# Altium schematic sheet 3 - 19V Buck.SchDoc
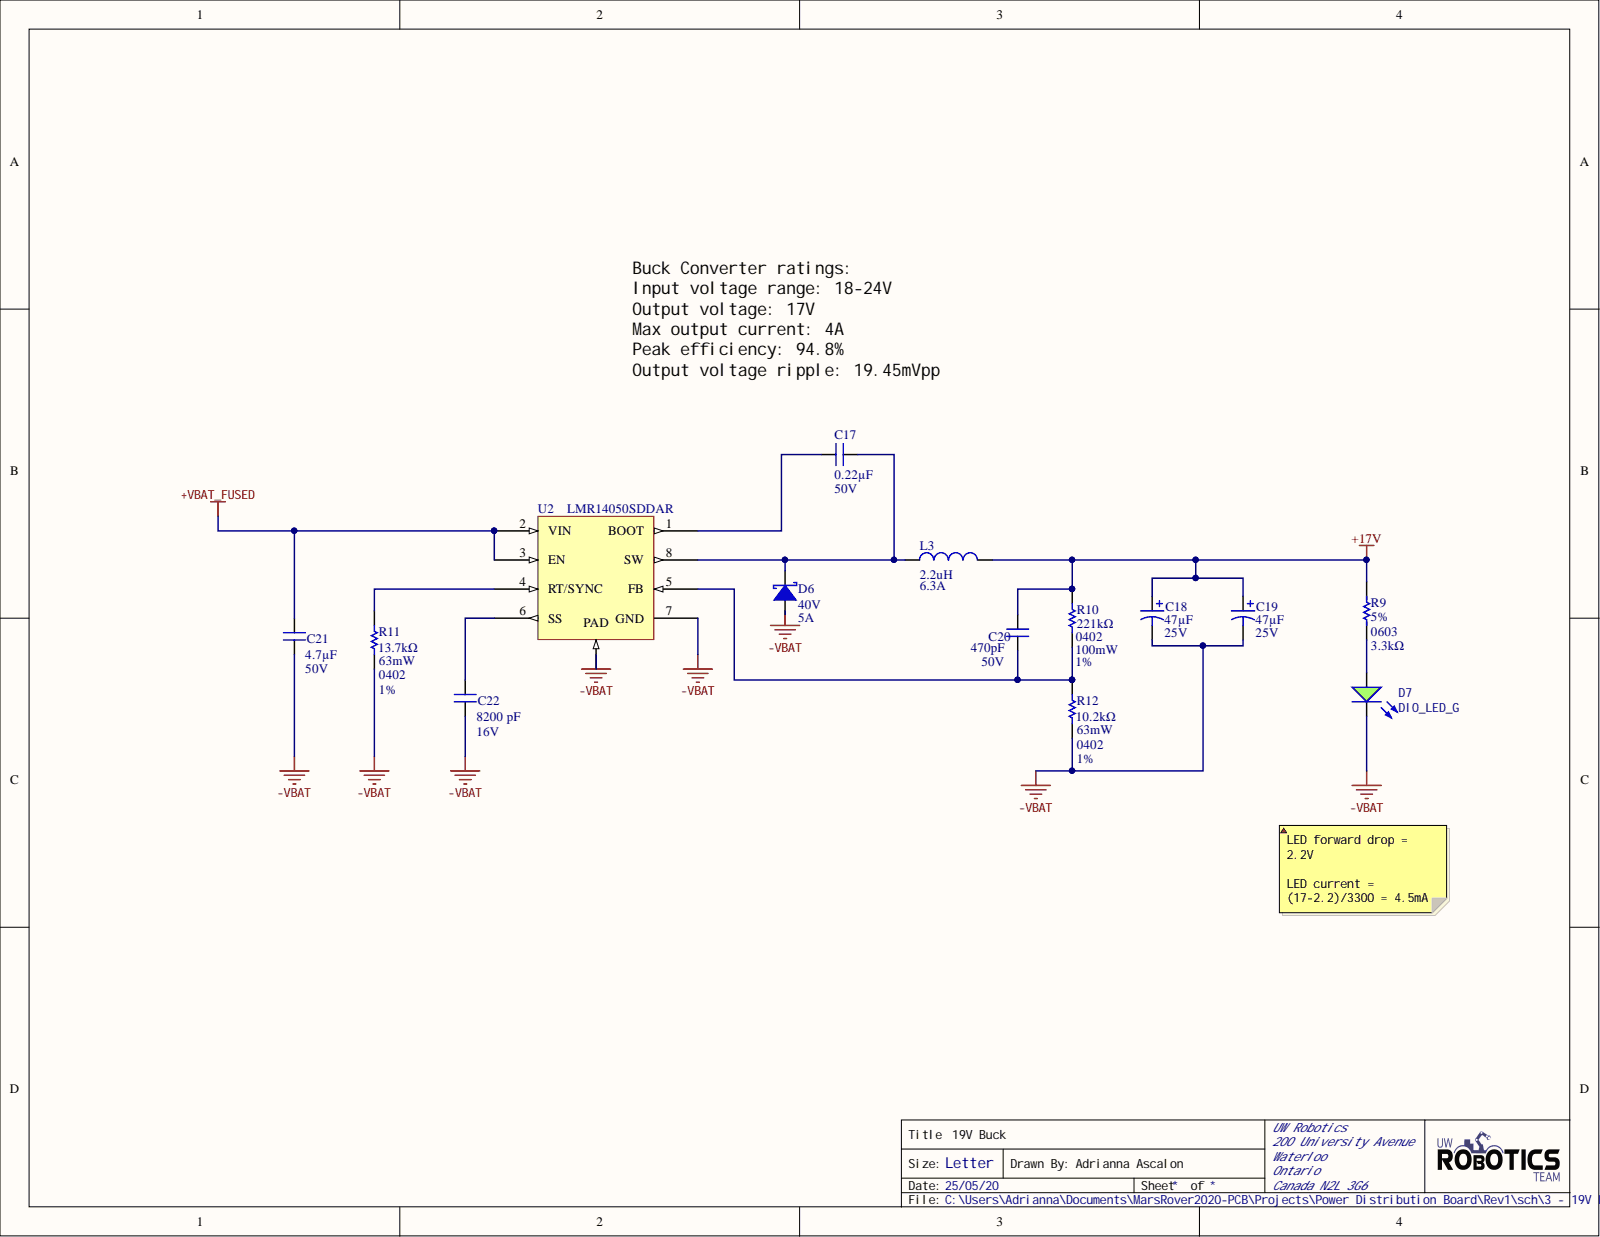
[diagram p0: 3 - 19V Buck.SchDoc]

## Parts
C17  Capacitance: 0.22µF  Voltage Rating: 50V  (hidden comment: GRM21BR71H224MA01L)
C18  Capacitance: 47µF  Voltage: 25V  (hidden comment: 25SVPF47M)
C19  Capacitance: 47µF  Voltage: 25V  (hidden comment: 25SVPF47M)
C20  Capacitance: 470pF  Voltage: 50V  (hidden comment: GRM155R71H471KA01D)
C21  value 4.7µF  Capacitance: 4.7µF  Voltage: 50V  (hidden comment: GRM31CR71H475KA12L)
C22  Voltage Rating: 16V  (hidden comment: GRM155R71C822KA01D)
D6  Average Rectified Current: 5A  Max Repetitive Reverse Voltage (Vrrm): 40V  (hidden comment: B540C-13-F)
D7  DIO_LED_G  [LED_0805_LINE]
L3  Current Rating: 6.3A  Inductance: 2.2uH  (hidden comment: SRN8040-2R2Y)
R9  value 3.3kΩ  Case/Package: 0603  Tolerance: 5%  [RESC0603(1608)_N]  (hidden comment: RES_3.3kΩ_0603)
R10  Case/Package: 0402  Max Power Dissipation: 100mW  Resistance: 221kΩ  Tolerance: 1%  (hidden comment: ERJ-2RKF2213X)
R11  Case/Package: 0402  Power Rating: 63mW  Resistance: 13.7kΩ  Tolerance: 1%  (hidden comment: CRCW040213K7FKED)
R12  Case/Package: 0402  Max Power Dissipation: 63mW  Resistance: 10.2kΩ  Tolerance: 1%  (hidden comment: CRCW040210K2FKED)
U2  LMR14050SDDAR

## Nets
+17V: C18.1 C19.1 C20.2 L3.2 R9.2 R10.2
+VBAT_FUSED: C21.1 U2.2(VIN) U2.3(EN)
-VBAT: C18.2 C19.2 C21.2 C22.1 D6.1 D7.2 R11.1 R12.1 U2.7(GND) U2.9
NetC17_1: C17.1 D6.2 L3.1 U2.8(SW)
NetC17_2: C17.2 U2.1(BOOT)
NetC20_1: C20.1 R10.1 R12.2 U2.5(FB)
NetC22_2: C22.2 U2.6(SS)
NetD7_1: D7.1 R9.1
NetR11_2: R11.2 U2.4(RT/SYNC)

## Library data of the parts
C17 = GRM21BR71H224MA01L: CAP CER 0.22UF 50V X7R 0805; Manufacturer 1: Murata; Tolerance: 20%; Case/Package: 0805; Case Code (Imperial): 0805; Case Code (Metric): 2012; Component Kind: Standard; ComponentLink1Description: https://datasheet.ciiva.com/5421/product-5421097.pdf?src-supplier=Mouser; ComponentLink1URL: https://datasheet.ciiva.com/5421/product-5421097.pdf?src-supplier=Mouser; ComponentLink2Description: https://datasheet.ciiva.com/136/partnumbering-e-01-136536.pdf?src-supplier=Digi-Key; ComponentLink2URL: https://datasheet.ciiva.com/136/partnumbering-e-01-136536.pdf?src-supplier=Digi-Key; ComponentLink3Description: https://datasheet.ciiva.com/136/partnumbering-e-01-136537.pdf?src-supplier=Digi-Key; ComponentLink3URL: https://datasheet.ciiva.com/136/partnumbering-e-01-136537.pdf?src-supplier=Digi-Key; ComponentLink4Description: https://datasheet.ciiva.com/136/partnumbering-e-01-136538.pdf?src-supplier=Digi-Key; ComponentLink4URL: https://datasheet.ciiva.com/136/partnumbering-e-01-136538.pdf?src-supplier=Digi-Key; Depth: 1.25mm; Dielectric: X7R; Height: 1.25mm; Lead Free: Lead Free; Length: 2mm; Manufacturer Part Number 1: GRM21BR71H224MA01L; Max Operating Temperature: 125°C; Min Operating Temperature: -55°C; Mount: Surface Mount; Package Quantity: 1; Packaging: Tape and Reel; Pricing 1: 1=0.33000, 10=0.23100, 100=0.13850, 500=0.10388, 1000=0.08772 (USD); Radiation Hardening: No; RoHS: Yes; Stock 1: 7809; Supplier 1: Digi-Key; Supplier Part Number 1: 490-1671-1-ND; Termination: SMD/SMT; Thickness: 0.053inch; Voltage Rating (DC): 50V; Width: 0.049inch; Library Name: UWRT_Schematic_Library_2020.SchLib
C18 C19 = 25SVPF47M: CAP ALUM POLY 47UF 20% 25V SMD; Manufacturer 1: Panasonic; Dissipation Factor: 12%; ESR (Equivalent Series Resistance): 30mR; Leakage Current: 235uA; Life (Hours): 5000Hour; Polarity: Polar; Ripple Current: 2.8A; Case/Package: Radial; Component Kind: Standard; ComponentLink1Description: https://datasheet.ciiva.com/22746/1734360-22746218.pdf?src-supplier=Element14; ComponentLink1URL: https://datasheet.ciiva.com/22746/1734360-22746218.pdf?src-supplier=Element14; ComponentLink2Description: https://datasheet.ciiva.com/24025/svpf-os-262415-24025725.pdf?src-supplier=Mouser; ComponentLink2URL: https://datasheet.ciiva.com/24025/svpf-os-262415-24025725.pdf?src-supplier=Mouser; ComponentLink3Description: https://datasheet.ciiva.com/48542/svpf-eng-ds-48542390.pdf?src-supplier=Distrelec; ComponentLink3URL: https://datasheet.ciiva.com/48542/svpf-eng-ds-48542390.pdf?src-supplier=Distrelec; ComponentLink4Description: https://datasheet.ciiva.com/25746/1734360-25746558.pdf?src-supplier=Farnell; ComponentLink4URL: https://datasheet.ciiva.com/25746/1734360-25746558.pdf?src-supplier=Farnell; Diameter: 6.3mm; Height: 5.9mm; Height - Seated (Max): 0.236inch; Length: 5.9mm; Manufacturer Part Number 1: 25SVPF47M; Max Operating Temperature: 105°C; Min Operating Temperature: -55°C; Mount: Surface Mount; Number of Pins: 6; Package Quantity: 1; Packaging: Tape and Reel; Pricing 1: 1=1.29000, 10=1.02200, 100=0.76620, 500=0.57888 (USD); REACH SVHC: No SVHC; Ripple Current (AC): 2.8A; RoHS: Yes; Stock 1: 6381; Supplier 1: Digi-Key; Supplier Part Number 1: P16513CT-ND; Termination: SMD/SMT; Tolerance: 20%; Voltage Rating: 25V; Voltage Rating (DC): 25V; Library Name: UWRT_Schematic_Library_2020.SchLib
C20 = GRM155R71H471KA01D: CAP CER 470PF 50V X7R 0402; Manufacturer 1: Murata; Material: Ceramic; Tolerance: 10%; Case/Package: 0402; Case Code (Imperial): 0402; Case Code (Metric): 1005; Component Kind: Standard; ComponentLink1Description: https://datasheet.ciiva.com/11786/0900766b80ef921d-11786912.pdf?src-supplier=RSComponents; ComponentLink1URL: https://datasheet.ciiva.com/11786/0900766b80ef921d-11786912.pdf?src-supplier=RSComponents; ComponentLink2Description: https://datasheet.ciiva.com/18101/getdatasheetpartid-37722-18101030.pdf?src-supplier=Verical; ComponentLink2URL: https://datasheet.ciiva.com/18101/getdatasheetpartid-37722-18101030.pdf?src-supplier=Verical; ComponentLink3Description: https://datasheet.ciiva.com/19476/1856505-19476137.pdf?src-supplier=Jameco; ComponentLink3URL: https://datasheet.ciiva.com/19476/1856505-19476137.pdf?src-supplier=Jameco; ComponentLink4Description: https://datasheet.ciiva.com/29199/454340-da-01-en-vs-kondensator-0402-29199656.pdf?src-supplier=Conrad; ComponentLink4URL: https://datasheet.ciiva.com/29199/454340-da-01-en-vs-kondensator-0402-29199656.pdf?src-supplier=Conrad; ComponentLink5Description: https://datasheet.ciiva.com/129/partnumbering-e-01-129843.pdf?src-supplier=Digi-Key; ComponentLink5URL: https://datasheet.ciiva.com/129/partnumbering-e-01-129843.pdf?src-supplier=Digi-Key; ComponentLink6Description: https://datasheet.ciiva.com/129/partnumbering-e-01-129844.pdf?src-supplier=Digi-Key; ComponentLink6URL: https://datasheet.ciiva.com/129/partnumbering-e-01-129844.pdf?src-supplier=Digi-Key; ComponentLink7Description: https://datasheet.ciiva.com/129/partnumbering-e-01-129845.pdf?src-supplier=Digi-Key; ComponentLink7URL: https://datasheet.ciiva.com/129/partnumbering-e-01-129845.pdf?src-supplier=Digi-Key; ComponentLink8Description: https://datasheet.ciiva.com/14116/grmseries-highdielectrictype-eng-14116837.pdf?src-supplier=Distrelec; ComponentLink8URL: https://datasheet.ciiva.com/14116/grmseries-highdielectrictype-eng-14116837.pdf?src-supplier=Distrelec; ComponentLink9Description: https://datasheet.ciiva.com/22698/608985-22698425.pdf?src-supplier=Element14; ComponentLink9URL: https://datasheet.ciiva.com/22698/608985-22698425.pdf?src-supplier=Element14; ComponentLink10Description: https://datasheet.ciiva.com/16199/product-16199180.pdf?src-supplier=Future+Electronics; ComponentLink10URL: https://datasheet.ciiva.com/16199/product-16199180.pdf?src-supplier=Future+Electronics; ComponentLink11Description: https://datasheet.ciiva.com/7937/608985-7937630.pdf?src-supplier=Element14; ComponentLink11URL: https://datasheet.ciiva.com/7937/608985-7937630.pdf?src-supplier=Element14; Depth: 0.5mm; Dielectric: X7R; Height: 0.5mm; Lead Free: Lead Free; Length: 1mm; Manufacturer Part Number 1: GRM155R71H471KA01D; Max Operating Temperature: 125°C; Min Operating Temperature: -55°C; Mount: Surface Mount; Number of Pins: 2; Package Quantity: 1; Packaging: Tape and Reel; Pricing 1: 1=0.10000, 10=0.05400, 100=0.02420, 500=0.01728, 1000=0.01358, 2500=0.01235, 5000=0.01136 (USD); Radiation Hardening: No; REACH SVHC: No SVHC; RoHS: Yes; Stock 1: 1593174; Supplier 1: Digi-Key; Supplier Part Number 1: 490-1300-1-ND; Termination: SMD/SMT; Thickness: 0.022inch; Voltage Rating: 50V; Voltage Rating (DC): 50V; Width: 0.02inch; Library Name: UWRT_Schematic_Library_2020.SchLib
C21 = GRM31CR71H475KA12L: CAP CER 4.7UF 50V X7R 1206; Manufacturer 1: Murata; Material: Ceramic; Tolerance: 10%; Case/Package: 1206; Case Code (Imperial): 1206; Case Code (Metric): 3216; Component Kind: Standard; ComponentLink1Description: https://datasheet.ciiva.com/6072/415379-6072784.pdf?src-supplier=Farnell; ComponentLink1URL: https://datasheet.ciiva.com/6072/415379-6072784.pdf?src-supplier=Farnell; ComponentLink2Description: https://datasheet.ciiva.com/3777/2267grm31cr71h475ka1223-3777241.pdf?src-supplier=Arrow; ComponentLink2URL: https://datasheet.ciiva.com/3777/2267grm31cr71h475ka1223-3777241.pdf?src-supplier=Arrow; ComponentLink3Description: https://datasheet.ciiva.com/29202/450972-da-01-de-vs-chip-kond-1206-4-7-uf-10pr-50-0v-x7r-29202576.pdf?src-supplier=Conrad; ComponentLink3URL: https://datasheet.ciiva.com/29202/450972-da-01-de-vs-chip-kond-1206-4-7-uf-10pr-50-0v-x7r-29202576.pdf?src-supplier=Conrad; ComponentLink4Description: https://datasheet.ciiva.com/29202/451279-da-01-de-vs-kondens-grm31-cr71h-475ka12l-29202365.pdf?src-supplier=Conrad; ComponentLink4URL: https://datasheet.ciiva.com/29202/451279-da-01-de-vs-kondens-grm31-cr71h-475ka12l-29202365.pdf?src-supplier=Conrad; ComponentLink5Description: https://datasheet.ciiva.com/7937/415310-7937749.pdf?src-supplier=Element14; ComponentLink5URL: https://datasheet.ciiva.com/7937/415310-7937749.pdf?src-supplier=Element14; ComponentLink6Description: https://datasheet.ciiva.com/6270/partnumbering-e-01-6270217.pdf?src-supplier=Digi-Key; ComponentLink6URL: https://datasheet.ciiva.com/6270/partnumbering-e-01-6270217.pdf?src-supplier=Digi-Key; ComponentLink7Description: https://datasheet.ciiva.com/6270/partnumbering-e-01-6270218.pdf?src-supplier=Digi-Key; ComponentLink7URL: https://datasheet.ciiva.com/6270/partnumbering-e-01-6270218.pdf?src-supplier=Digi-Key; ComponentLink8Description: https://datasheet.ciiva.com/6270/partnumbering-e-01-6270219.pdf?src-supplier=Digi-Key; ComponentLink8URL: https://datasheet.ciiva.com/6270/partnumbering-e-01-6270219.pdf?src-supplier=Digi-Key; Depth: 1.6mm; Dielectric: X7R; Height: 1.6mm; Lead Free: Lead Free; Length: 3.2mm; Manufacturer Part Number 1: GRM31CR71H475KA12L; Max Operating Temperature: 125°C; Min Operating Temperature: -55°C; Mount: Surface Mount; Package Quantity: 2000; Packaging: Tape and Reel; Pricing 1: 1=0.86000, 10=0.63700, 100=0.43660, 500=0.34562, 1000=0.29105 (USD); Radiation Hardening: No; REACH SVHC: No SVHC; RoHS: Yes; Stock 1: 12089; Supplier 1: Digi-Key; Supplier Part Number 1: 490-6521-1-ND; Termination: SMD/SMT; Thickness: 0.071inch; Voltage Rating: 50V; Voltage Rating (DC): 50V; Width: 0.063inch; Library Name: UWRT_Schematic_Library_2020.SchLib
C22 = GRM155R71C822KA01D: CAP CER 8200PF 16V X7R 0402; Manufacturer 1: Murata; Tolerance: 10%; Capacitance: 8200 pF; Case/Package: 0402; Case Code (Imperial): 0402; Case Code (Metric): 1005; Component Kind: Standard; ComponentLink1Description: https://datasheet.ciiva.com/11619/product-11619716.pdf?src-supplier=Avnet; ComponentLink1URL: https://datasheet.ciiva.com/11619/product-11619716.pdf?src-supplier=Avnet; ComponentLink2Description: https://datasheet.ciiva.com/18184/getdatasheetpartid-163702-18184683.pdf?src-supplier=Verical; ComponentLink2URL: https://datasheet.ciiva.com/18184/getdatasheetpartid-163702-18184683.pdf?src-supplier=Verical; ComponentLink3Description: https://datasheet.ciiva.com/6263/partnumbering-e-01-6263702.pdf?src-supplier=Digi-Key; ComponentLink3URL: https://datasheet.ciiva.com/6263/partnumbering-e-01-6263702.pdf?src-supplier=Digi-Key; ComponentLink4Description: https://datasheet.ciiva.com/6263/partnumbering-e-01-6263704.pdf?src-supplier=Digi-Key; ComponentLink4URL: https://datasheet.ciiva.com/6263/partnumbering-e-01-6263704.pdf?src-supplier=Digi-Key; ComponentLink5Description: https://datasheet.ciiva.com/6263/partnumbering-e-01-6263706.pdf?src-supplier=Digi-Key; ComponentLink5URL: https://datasheet.ciiva.com/6263/partnumbering-e-01-6263706.pdf?src-supplier=Digi-Key; Depth: 0.5mm; Dielectric: X7R; Height: 0.5mm; Lead Free: Lead Free; Length: 1mm; Manufacturer Part Number 1: GRM155R71C822KA01D; Max Operating Temperature: 125°C; Min Operating Temperature: -55°C; Mount: Surface Mount; Package Quantity: 1; Packaging: Tape and Reel; Pricing 1: 1=0.10000, 10=0.04500, 100=0.01980, 500=0.01416, 1000=0.01113, 2500=0.01012, 5000=0.00931 (USD); Radiation Hardening: No; RoHS: Yes; Stock 1: 72521; Supplier 1: Digi-Key; Supplier Part Number 1: 490-6338-1-ND; Termination: SMD/SMT; Thickness: 0.022inch; Voltage Rating (DC): 16V; Width: 0.02inch; Library Name: UWRT_Schematic_Library_2020.SchLib
D6 = B540C-13-F: Diode Schottky 40V 5A Surface Mount SMC; Manufacturer 1: Diodes; Capacitance: 300pF; Element Configuration: Single; Forward Voltage: 550mV; Max Forward Surge Current (Ifsm): 100A; Peak Reverse Current: 500uA; Polarity: Standard; Case/Package: SMC; Component Kind: Standard; ComponentLink1Description: https://datasheet.ciiva.com/914/ds13012-914960.pdf?src-supplier=Digi-Key; ComponentLink1URL: https://datasheet.ciiva.com/914/ds13012-914960.pdf?src-supplier=Digi-Key; ComponentLink2Description: https://datasheet.ciiva.com/26949/getdatasheetpartid-184980-26949363.pdf?src-supplier=Verical; ComponentLink2URL: https://datasheet.ciiva.com/26949/getdatasheetpartid-184980-26949363.pdf?src-supplier=Verical; ComponentLink3Description: https://datasheet.ciiva.com/26788/b540c-13-f-26788854.pdf?src-supplier=IIIC; ComponentLink3URL: https://datasheet.ciiva.com/26788/b540c-13-f-26788854.pdf?src-supplier=IIIC; ComponentLink4Description: https://datasheet.ciiva.com/1177/ds13012-1177530.pdf?src-supplier=Element14; ComponentLink4URL: https://datasheet.ciiva.com/1177/ds13012-1177530.pdf?src-supplier=Element14; ComponentLink5Description: https://datasheet.ciiva.com/914/ds13012-914958.pdf?src-supplier=Digi-Key; ComponentLink5URL: https://datasheet.ciiva.com/914/ds13012-914958.pdf?src-supplier=Digi-Key; ComponentLink6Description: https://datasheet.ciiva.com/914/ds13012-914959.pdf?src-supplier=Digi-Key; ComponentLink6URL: https://datasheet.ciiva.com/914/ds13012-914959.pdf?src-supplier=Digi-Key; ComponentLink7Description: https://datasheet.ciiva.com/8303/ds13012-8303112.pdf?src-supplier=Avnet; ComponentLink7URL: https://datasheet.ciiva.com/8303/ds13012-8303112.pdf?src-supplier=Avnet; ComponentLink8Description: https://datasheet.ciiva.com/25919/ds13012-25919706.pdf?src-supplier=Avnet+Europe; ComponentLink8URL: https://datasheet.ciiva.com/25919/ds13012-25919706.pdf?src-supplier=Avnet+Europe; ComponentLink9Description: https://datasheet.ciiva.com/16200/ds13012-16200443.pdf?src-supplier=Future+Electronics; ComponentLink9URL: https://datasheet.ciiva.com/16200/ds13012-16200443.pdf?src-supplier=Future+Electronics; Contact Plating: Tin,Matte; Current: 5A; Current Rating: 5A; Forward Current: 5A; Height: 2.5mm; Lead Free: Lead Free; Length: 7.11mm; Manufacturer Part Number 1: B540C-13-F; Max Operating Temperature: 125°C; Max Reverse Voltage (DC): 40V; Min Operating Temperature: -55°C; Mount: Surface Mount; Number of Pins: 2; Output Current: 5A; Package Quantity: 3000; Packaging: Tape and Reel; Peak Non-Repetitive Surge Current: 100A; Pricing 1: 1=0.49000, 10=0.41700, 25=0.38880, 100=0.28890, 250=0.27440, 500=0.22554, 1000=0.18332 (USD); Radiation Hardening: No; REACH SVHC: No SVHC; RoHS: Yes; Stock 1: 27000; Supplier 1: Digi-Key; Supplier Part Number 1: B540C-FDIDKR-ND; Termination: SMD/SMT; Voltage: 40V; Voltage Rating (DC): 40V; Weight: 0.007408oz; Width: 6.22mm; Library Name: UWRT_Schematic_Library_2020.SchLib
D7 = DIO_LED_G: LED GREEN DIFFUSED 0805 SMD; Manufacturer 1: OSRAM; Manufacturer Part Number 1: LG R971-KN-1; Forward Voltage Drop: 2.2V; Component Kind: Standard; Mount: Surface Mount; Package: 0805 (2012 Metric); Simulation: LED1; Supplier 1: Digi-Key; Supplier Part Number 1: 475-1410-1-ND; Library Name: UWRT_Schematic_Library_2020.SchLib
L3 = SRN8040-2R2Y: FIXED IND 2.2UH 6.3A 13 MOHM SMD; Manufacturer 1: Bourns; Core Material: Ferrite; DC Resistance (DCR): 13mR; Inductance Tolerance: 30%; Material: Steel; Saturation Current: 7.4A; Self Resonant Frequency: 40MHz; Shielding: Shielded; Test Frequency: 100kHz; Case/Package: Nonstandard; Component Kind: Standard; ComponentLink1Description: https://datasheet.ciiva.com/11802/0900766b80fe1f3f-11802605.pdf?src-supplier=RSComponents; ComponentLink1URL: https://datasheet.ciiva.com/11802/0900766b80fe1f3f-11802605.pdf?src-supplier=RSComponents; ComponentLink2Description: https://datasheet.ciiva.com/28678/srn8040-28678249.pdf?src-supplier=Element14; ComponentLink2URL: https://datasheet.ciiva.com/28678/srn8040-28678249.pdf?src-supplier=Element14; ComponentLink3Description: https://datasheet.ciiva.com/8515/srn8040-8515258.pdf?src-supplier=Avnet; ComponentLink3URL: https://datasheet.ciiva.com/8515/srn8040-8515258.pdf?src-supplier=Avnet; ComponentLink4Description: https://datasheet.ciiva.com/7847/1865628-7847915.pdf?src-supplier=Element14; ComponentLink4URL: https://datasheet.ciiva.com/7847/1865628-7847915.pdf?src-supplier=Element14; ComponentLink5Description: https://datasheet.ciiva.com/1049/srn8040-1049453.pdf?src-supplier=Digi-Key; ComponentLink5URL: https://datasheet.ciiva.com/1049/srn8040-1049453.pdf?src-supplier=Digi-Key; ComponentLink6Description: https://datasheet.ciiva.com/1049/srn8040-1049454.pdf?src-supplier=Digi-Key; ComponentLink6URL: https://datasheet.ciiva.com/1049/srn8040-1049454.pdf?src-supplier=Digi-Key; ComponentLink7Description: https://datasheet.ciiva.com/1049/srn8040-1049455.pdf?src-supplier=Digi-Key; ComponentLink7URL: https://datasheet.ciiva.com/1049/srn8040-1049455.pdf?src-supplier=Digi-Key; ComponentLink8Description: https://datasheet.ciiva.com/17284/srn8040-17284783.pdf?src-supplier=Avnet+Europe; ComponentLink8URL: https://datasheet.ciiva.com/17284/srn8040-17284783.pdf?src-supplier=Avnet+Europe; ComponentLink9Description: https://datasheet.ciiva.com/48691/001056460-da-01-en-mag-abg-leist-ind-srn8040-2r2y-2-2uh-smd-48691836.pdf?src-supplier=Conrad; ComponentLink9URL: https://datasheet.ciiva.com/48691/001056460-da-01-en-mag-abg-leist-ind-srn8040-2r2y-2-2uh-smd-48691836.pdf?src-supplier=Conrad; Composition: Wirewound; Contact Plating: Tin; DC Current: 6.3A; Depth: 8.3mm; Height: 4mm; Height - Seated (Max): 0.157inch; Length: 8mm; Manufacturer Part Number 1: SRN8040-2R2Y; Max DC Current: 6.3A; Max Operating Temperature: 125°C; Military Standard: Not; Min Operating Temperature: -40°C; Mount: Surface Mount; Number of Pins: 2; Package Quantity: 1000; Packaging: Tape and Reel; Pricing 1: 1=0.78000, 10=0.68400, 100=0.48880, 500=0.41056 (USD); REACH SVHC: No SVHC; RMS Current (Irms): 6.3A; RoHS: Yes; Stock 1: 4815; Supplier 1: Digi-Key; Supplier Part Number 1: SRN8040-2R2YCT-ND; Termination: SMD/SMT; Tolerance: 30%; Weight: 0.028607oz; Width: 8mm; Library Name: UWRT_Schematic_Library_2020.SchLib
R9 = RES_3.3kΩ_0603: RES SMD 3.3K OHM 5% 1/10W 0603; Manufacturer 1: Yageo; Manufacturer Part Number 1: RC0603JR-073K3L; Case Code (Metric): 1608; Component Kind: Standard; Dimensions: 0.063" L x 0.031" W (1.60mm x 0.80mm); Mount: Surface Mount; Package: 0603 (1608 Metric); Signal Integrity: Res; Simulation: RESISTOR; Supplier 1: Digi-Key; Supplier Part Number 1: 311-3.3KGRCT-ND; Library Name: UWRT_Schematic_Library_2020.SchLib
R10 = ERJ-2RKF2213X: RES SMD 221K OHM 1% 1/10W 0402; Manufacturer 1: Panasonic; Temperature Coefficient: 100ppm/°C; Voltage Rating: 50V; Case Code (Imperial): 0402; Case Code (Metric): 1005; Component Kind: Standard; ComponentLink1Description: https://datasheet.ciiva.com/43467/1678782-43467510.pdf?src-supplier=Farnell; ComponentLink1URL: https://datasheet.ciiva.com/43467/1678782-43467510.pdf?src-supplier=Farnell; ComponentLink2Description: https://datasheet.ciiva.com/7712/1678782-7712808.pdf?src-supplier=Element14; ComponentLink2URL: https://datasheet.ciiva.com/7712/1678782-7712808.pdf?src-supplier=Element14; ComponentLink3Description: https://datasheet.ciiva.com/9184/aoa0000ce2-9184216.pdf?src-supplier=Avnet; ComponentLink3URL: https://datasheet.ciiva.com/9184/aoa0000ce2-9184216.pdf?src-supplier=Avnet; ComponentLink4Description: https://datasheet.ciiva.com/16514/aoa0000ce2-16514280.pdf?src-supplier=Avnet+Asia; ComponentLink4URL: https://datasheet.ciiva.com/16514/aoa0000ce2-16514280.pdf?src-supplier=Avnet+Asia; ComponentLink5Description: https://datasheet.ciiva.com/46373/1678782-46373065.pdf?src-supplier=Element14; ComponentLink5URL: https://datasheet.ciiva.com/46373/1678782-46373065.pdf?src-supplier=Element14; ComponentLink6Description: https://datasheet.ciiva.com/46373/1678782-46373066.pdf?src-supplier=Element14; ComponentLink6URL: https://datasheet.ciiva.com/46373/1678782-46373066.pdf?src-supplier=Element14; ComponentLink7Description: https://datasheet.ciiva.com/16777/70260748-16777838.pdf?src-supplier=Allied+Electronics; ComponentLink7URL: https://datasheet.ciiva.com/16777/70260748-16777838.pdf?src-supplier=Allied+Electronics; ComponentLink8Description: https://datasheet.ciiva.com/24026/aoa0000ce2-24026895.pdf?src-supplier=Mouser; ComponentLink8URL: https://datasheet.ciiva.com/24026/aoa0000ce2-24026895.pdf?src-supplier=Mouser; Composition: Thick Film; Contact Plating: Tin; Depth: 0.5mm; Features: Automotive AEC-Q200; Height: 0.016inch; Lead Free: Lead Free; Length: 1mm; Manufacturer Part Number 1: ERJ-2RKF2213X; Max Operating Temperature: 155°C; Military Standard: Not; Min Operating Temperature: -55°C; Mount: Surface Mount; Number of Terminations: 2; Package Quantity: 1; Packaging: Tape and Reel; Power Rating: 100mW; Pricing 1: 1=0.10000, 10=0.04100, 100=0.01660, 1000=0.00745, 2500=0.00646, 5000=0.00534 (USD); REACH SVHC: No SVHC; RoHS: Yes; Stock 1: 643641; Supplier 1: Digi-Key; Supplier Part Number 1: P221KLCT-ND; Termination: SMD/SMT; Voltage Rating (DC): 50V; Width: 0.02inch; Library Name: UWRT_Schematic_Library_2020.SchLib
R11 = CRCW040213K7FKED: RES SMD 13.7K OHM 1% 1/16W 0402; Manufacturer 1: Vishay; Temperature Coefficient: 100ppm/°C; Voltage Rating: 50V; Case Code (Imperial): 0402; Case Code (Metric): 1005; Component Kind: Standard; ComponentLink1Description: https://datasheet.ciiva.com/7664/1533075-7664006.pdf?src-supplier=Element14; ComponentLink1URL: https://datasheet.ciiva.com/7664/1533075-7664006.pdf?src-supplier=Element14; ComponentLink2Description: https://datasheet.ciiva.com/5561/dcrcwe3-109170-5561994.pdf?src-supplier=Mouser; ComponentLink2URL: https://datasheet.ciiva.com/5561/dcrcwe3-109170-5561994.pdf?src-supplier=Mouser; ComponentLink3Description: https://datasheet.ciiva.com/16775/70201119-16775342.pdf?src-supplier=Allied+Electronics; ComponentLink3URL: https://datasheet.ciiva.com/16775/70201119-16775342.pdf?src-supplier=Allied+Electronics; ComponentLink4Description: https://datasheet.ciiva.com/22871/70201119-22871774.pdf?src-supplier=Allied+Electronics; ComponentLink4URL: https://datasheet.ciiva.com/22871/70201119-22871774.pdf?src-supplier=Allied+Electronics; ComponentLink5Description: https://datasheet.ciiva.com/7663/1697337-7663831.pdf?src-supplier=Element14; ComponentLink5URL: https://datasheet.ciiva.com/7663/1697337-7663831.pdf?src-supplier=Element14; Composition: Thick Film; Contact Plating: Tin; Depth: 0.5mm; Height: 0.35mm; Lead Free: Lead Free; Length: 1mm; Manufacturer Part Number 1: CRCW040213K7FKED; Max Operating Temperature: 155°C; Military Standard: Not; Min Operating Temperature: -55°C; Number of Pins: 2; Package Quantity: 10000; Packaging: Tape and Reel; Pricing 1: 1=0.10000, 10=0.03600, 100=0.01460, 1000=0.00657, 2500=0.00570, 5000=0.00471 (USD); Radiation Hardening: No; REACH SVHC: Unknown; RoHS: Yes; Stock 1: 47823; Supplier 1: Digi-Key; Supplier Part Number 1: 541-13.7KLCT-ND; Termination: SMD/SMT; Voltage Rating (DC): 50V; Weight: 2.3E-05oz; Width: 0.5mm; Library Name: UWRT_Schematic_Library_2020.SchLib
R12 = CRCW040210K2FKED: RES SMD 10.2K OHM 1% 1/16W 0402; Manufacturer 1: Vishay; Temperature Coefficient: 100ppm/°C; Voltage Rating: 50V; Case Code (Imperial): 0402; Case Code (Metric): 1005; Component Kind: Standard; ComponentLink1Description: https://datasheet.ciiva.com/11810/0900766b80d98824-11810045.pdf?src-supplier=RSComponents; ComponentLink1URL: https://datasheet.ciiva.com/11810/0900766b80d98824-11810045.pdf?src-supplier=RSComponents; ComponentLink2Description: https://datasheet.ciiva.com/2525/dcrcwe3-2525638.pdf?src-supplier=Digi-Key; ComponentLink2URL: https://datasheet.ciiva.com/2525/dcrcwe3-2525638.pdf?src-supplier=Digi-Key; ComponentLink3Description: https://datasheet.ciiva.com/7369/1635184-7369334.pdf?src-supplier=Newark; ComponentLink3URL: https://datasheet.ciiva.com/7369/1635184-7369334.pdf?src-supplier=Newark; ComponentLink4Description: https://datasheet.ciiva.com/7663/1697337-7663814.pdf?src-supplier=Element14; ComponentLink4URL: https://datasheet.ciiva.com/7663/1697337-7663814.pdf?src-supplier=Element14; ComponentLink5Description: https://datasheet.ciiva.com/2525/dcrcwe3-2525639.pdf?src-supplier=Digi-Key; ComponentLink5URL: https://datasheet.ciiva.com/2525/dcrcwe3-2525639.pdf?src-supplier=Digi-Key; ComponentLink6Description: https://datasheet.ciiva.com/7663/1697337-7663815.pdf?src-supplier=Element14; ComponentLink6URL: https://datasheet.ciiva.com/7663/1697337-7663815.pdf?src-supplier=Element14; ComponentLink7Description: https://datasheet.ciiva.com/2525/dcrcwe3-2525640.pdf?src-supplier=Digi-Key; ComponentLink7URL: https://datasheet.ciiva.com/2525/dcrcwe3-2525640.pdf?src-supplier=Digi-Key; ComponentLink8Description: https://datasheet.ciiva.com/16881/dcrcwe3-16881433.pdf?src-supplier=Avnet+Europe; ComponentLink8URL: https://datasheet.ciiva.com/16881/dcrcwe3-16881433.pdf?src-supplier=Avnet+Europe; ComponentLink9Description: https://datasheet.ciiva.com/7743/125364-7743418.pdf?src-supplier=Element14; ComponentLink9URL: https://datasheet.ciiva.com/7743/125364-7743418.pdf?src-supplier=Element14; ComponentLink10Description: https://datasheet.ciiva.com/22870/70201119-22870522.pdf?src-supplier=Allied+Electronics; ComponentLink10URL: https://datasheet.ciiva.com/22870/70201119-22870522.pdf?src-supplier=Allied+Electronics; ComponentLink11Description: https://datasheet.ciiva.com/16772/70201119-16772093.pdf?src-supplier=Allied+Electronics; ComponentLink11URL: https://datasheet.ciiva.com/16772/70201119-16772093.pdf?src-supplier=Allied+Electronics; ComponentLink12Description: https://datasheet.ciiva.com/3255/dcrcwe3-3255966.pdf?src-supplier=Avnet; ComponentLink12URL: https://datasheet.ciiva.com/3255/dcrcwe3-3255966.pdf?src-supplier=Avnet; ComponentLink13Description: https://datasheet.ciiva.com/16394/dcrcwe3-16394143.pdf?src-supplier=Future+Electronics; ComponentLink13URL: https://datasheet.ciiva.com/16394/dcrcwe3-16394143.pdf?src-supplier=Future+Electronics; ComponentLink14Description: https://datasheet.ciiva.com/22702/1697337-22702863.pdf?src-supplier=Element14; ComponentLink14URL: https://datasheet.ciiva.com/22702/1697337-22702863.pdf?src-supplier=Element14; Composition: Thick Film; Contact Plating: Tin; Depth: 0.5mm; Features: Automotive AEC-Q200; Height: 0.35mm; Length: 1mm; Manufacturer Part Number 1: CRCW040210K2FKED; Max Operating Temperature: 155°C; Military Standard: Not; Min Operating Temperature: -55°C; Mount: Surface Mount; Number of Pins: 2; Number of Terminations: 2; Package Quantity: 10; Packaging: Tape and Reel; Power Rating: 63mW; Pricing 1: 1=0.10000, 10=0.03600, 100=0.01460, 1000=0.00657, 2500=0.00570, 5000=0.00471 (USD); Radiation Hardening: No; REACH SVHC: Unknown; RoHS: Yes; Stock 1: 5325; Supplier 1: Digi-Key; Supplier Part Number 1: 541-10.2KLCT-ND; Termination: SMD/SMT; Weight: 2.3E-05oz; Width: 0.5mm; Library Name: UWRT_Schematic_Library_2020.SchLib
U2 = LMR14050SDDAR: Buck, 40V, 5A  2.2MHz Step-Down Regulator with 40µA IQ 8-SO PowerPAD -40 to 125; Manufacturer 1: Texas Instruments; Max Output Voltage: 28V; Min Input Voltage: 4V; Min Output Voltage: 800mV; Number of Outputs: 1; Output Configuration: Positive; Output Type: Adjustable; Quiescent Current: 40uA; Switching Frequency: 2.5MHz; Synchronous Rectifier: No; Case/Package: 8-PowerSOIC; Component Kind: Standard; ComponentLink1Description: http://www.ti.com/general/docs/lit/getliterature.tsp?genericPartNumber=LMR14050&&fileType=pdf&src-supplier=Avnet; ComponentLink1URL: http://www.ti.com/general/docs/lit/getliterature.tsp?genericPartNumber=LMR14050&&fileType=pdf&src-supplier=Avnet; ComponentLink2Description: http://www.ti.com/lit/ds/symlink/lmr14050.pdf?src-supplier=Digi-Key; ComponentLink2URL: http://www.ti.com/lit/ds/symlink/lmr14050.pdf?src-supplier=Digi-Key; ComponentLink3Description: http://www.ti.com/general/docs/suppproductinfo.tsp?distId=10&amp;gotoUrl=http%3A%2F%2Fwww.ti.com%2Flit%2Fgpn%2Flmr14050&src-supplier=Digi-Key; ComponentLink3URL: http://www.ti.com/general/docs/suppproductinfo.tsp?distId=10&amp;gotoUrl=http%3A%2F%2Fwww.ti.com%2Flit%2Fgpn%2Flmr14050&src-supplier=Digi-Key; Lead Free: Lead Free; Manufacturer Part Number 1: LMR14050SDDAR; Max Input Voltage: 40V; Max Operating Temperature: 125°C; Min Operating Temperature: -40°C; Mount: Surface Mount; Number of Pins: 8; Output Current: 5A; Packaging: Cut Tape; Pricing 1: 1=2.80000, 10=2.51300, 25=2.37600, 100=1.95360, 250=1.75296, 500=1.68960, 1000=1.40448 (USD); RoHS: Yes; Stock 1: 5816; Supplier 1: Digi-Key; Supplier Part Number 1: 296-44322-1-ND; Library Name: UWRT_Schematic_Library_2020.SchLib
